# Altium schematic sheet FPGA_PWR_MISC.SchDoc(FPGA_PWR_MISC)
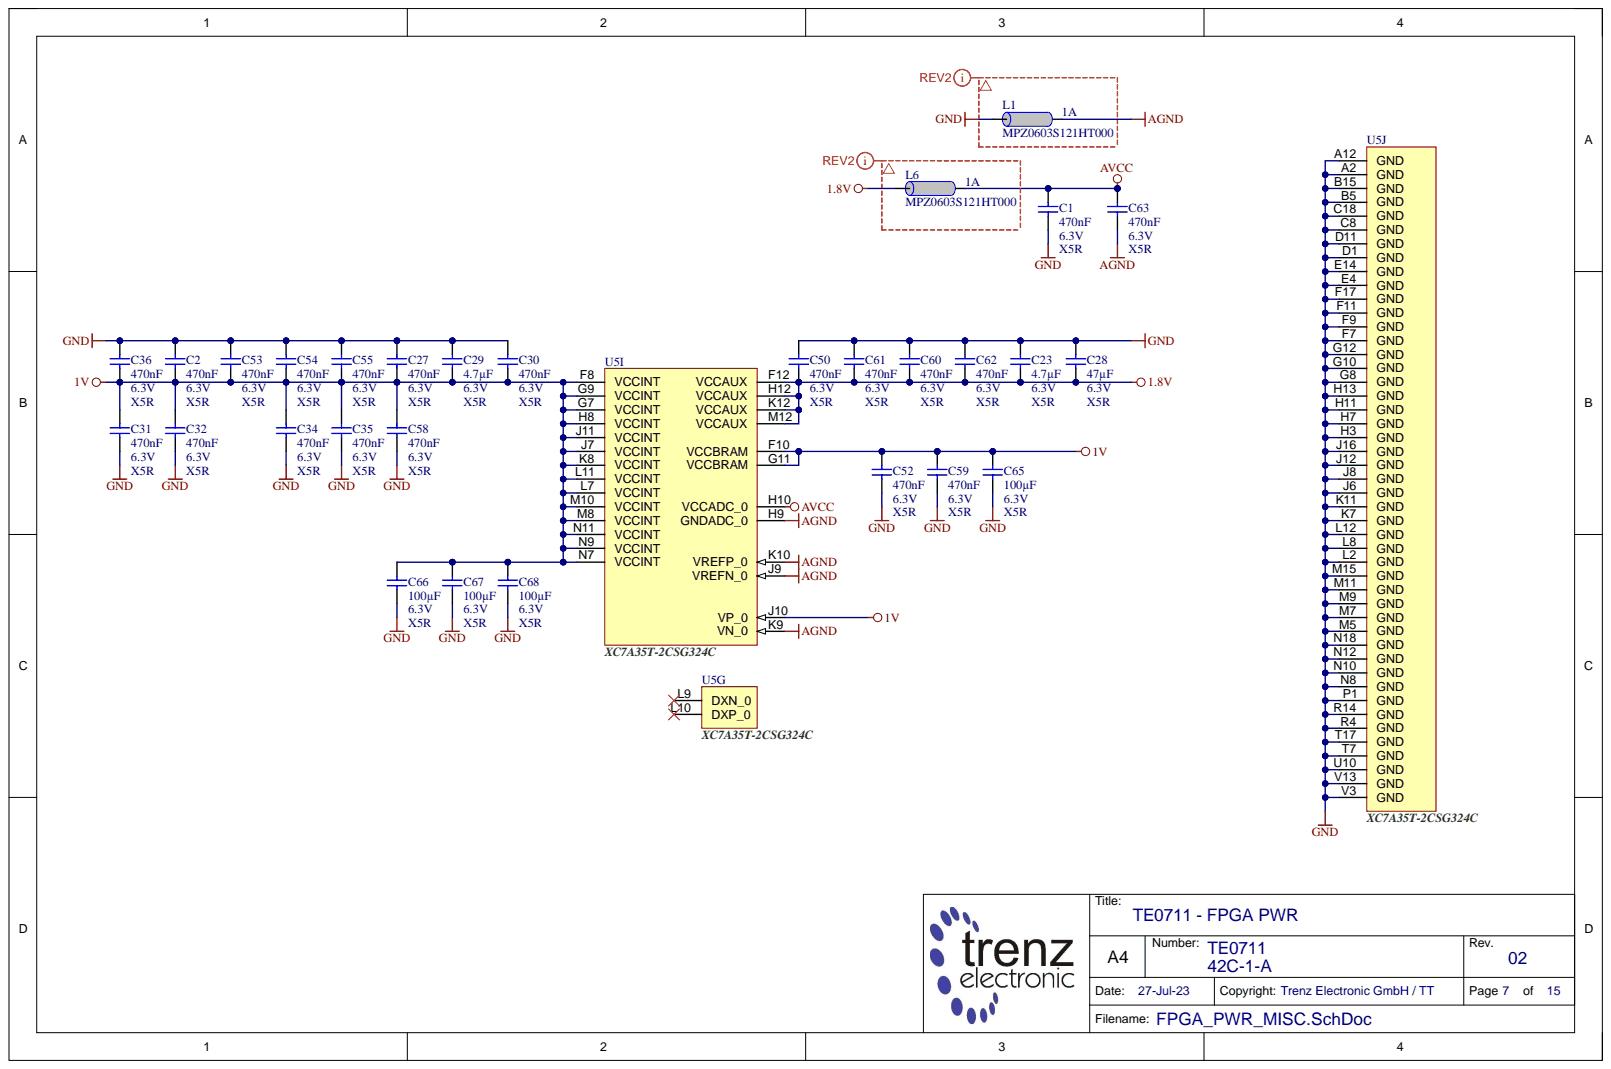
[diagram p0: FPGA_PWR_MISC.SchDoc]

## Parts
C1  470nF  Technology: X5R  Voltage: 6.3V  [CAPC0603X33N_V]
C2  470nF  Voltage: 6.3V  [CAPC0603X33N_V]
C23  4.7µF  Technology: X5R  Voltage: 6.3V  [CAPC1005X55N_V]
C27  470nF  Voltage: 6.3V  [CAPC0603X33N_V]
C28  47µF  Technology: X5R  Voltage: 6.3V  [CAPC2012X135N_V]
C29  4.7µF  Technology: X5R  Voltage: 6.3V  [CAPC1005X55N_V]
C30  470nF  Technology: X5R  Voltage: 6.3V  [CAPC0603X33N_V]
C31  470nF  Technology: X5R  Voltage: 6.3V  [CAPC0603X33N_V]
C32  470nF  Technology: X5R  Voltage: 6.3V  [CAPC0603X33N_V]
C34  470nF  Technology: X5R  Voltage: 6.3V  [CAPC0603X33N_V]
C35  470nF  Technology: X5R  Voltage: 6.3V  [CAPC0603X33N_V]
C36  470nF  Voltage: 6.3V  [CAPC0603X33N_V]
C50  470nF  Technology: X5R  Voltage: 6.3V  [CAPC0603X33N_V]
C52  470nF  Technology: X5R  Voltage: 6.3V  [CAPC0603X33N_V]
C53  470nF  Voltage: 6.3V  [CAPC0603X33N_V]
C54  470nF  Voltage: 6.3V  [CAPC0603X33N_V]
C55  470nF  Voltage: 6.3V  [CAPC0603X33N_V]
C58  470nF  Technology: X5R  Voltage: 6.3V  [CAPC0603X33N_V]
C59  470nF  Technology: X5R  Voltage: 6.3V  [CAPC0603X33N_V]
C60  470nF  Technology: X5R  Voltage: 6.3V  [CAPC0603X33N_V]
C61  470nF  Technology: X5R  Voltage: 6.3V  [CAPC0603X33N_V]
C62  470nF  Technology: X5R  Voltage: 6.3V  [CAPC0603X33N_V]
C63  470nF  Technology: X5R  Voltage: 6.3V  [CAPC0603X33N_V]
C65  100µF  Technology: X5R  Voltage: 6.3V  [CAPC3216X180N_V]
C66  100µF  Technology: X5R  Voltage: 6.3V  [CAPC3216X180N_V]
C67  100µF  Technology: X5R  Voltage: 6.3V  [CAPC3216X180N_V]
C68  100µF  Technology: X5R  Voltage: 6.3V  [CAPC3216X180N_V]
L1  MPZ0603S121HT000  Voltage: 1A  [INDC0603X33N_V]
L6  MPZ0603S121HT000  Voltage: 1A  [INDC0603X33N_V]
U5  XC7A35T-2CSG324C  [BGA324C80P18X18_1500X1500X150N]  (parts G,I,J)
U5
U5
U5
U5
U5
U5
U5
U5
U5

## Nets
1.8V: C23.1 C28.1 C50.2 C60.1 C61.1 C62.1 L6.2 U5.F12 U5.H12 U5.K12 U5.M12
1V: C2.2 C27.2 C29.1 C30.1 C31.2 C32.2 C34.2 C35.2 C36.2 C52.1 C53.2 C54.2 C55.2 C58.2 C59.1 C65.1 C66.1 C67.1 C68.1 U5.F8 U5.F10 U5.G7 U5.G9 U5.G11 U5.H8 U5.J7 U5.J10 U5.J11 U5.K8 U5.L7 U5.L11 U5.M8 U5.M10 U5.N7 U5.N9 U5.N11
AGND: C63.2 L1.1 U5.H9 U5.J9 U5.K9 U5.K10
AVCC: C1.1 C63.1 L6.1 U5.H10
GND: C1.2 C2.1 C23.2 C27.1 C28.2 C29.2 C30.2 C31.1 C32.1 C34.1 C35.1 C36.1 C50.1 C52.2 C53.1 C54.1 C55.1 C58.1 C59.2 C60.2 C61.2 C62.2 C65.2 C66.2 C67.2 C68.2 L1.2 U5.A2 U5.A12 U5.B5 U5.B15 U5.C8 U5.C18 U5.D1 U5.D11 U5.E4 U5.E14 U5.F7 U5.F9 U5.F11 U5.F17 U5.G8 U5.G10 U5.G12 U5.H3 U5.H7 U5.H11 U5.H13 U5.J6 U5.J8 U5.J12 U5.J16 U5.K7 U5.K11 U5.L2 U5.L8 U5.L12 U5.M5 U5.M7 U5.M9 U5.M11 U5.M15 U5.N8 U5.N10 U5.N12 U5.N18 U5.P1 U5.R4 U5.R14 U5.T7 U5.T17 U5.U10 U5.V3 U5.V13

Not connected: U5 L9 L10

## Library data of the parts
C1 C2 C27 C30-C32 C34-C36 C50 C52-C55 C58-C63 = 24921: KON 470nF 6.3V X5R 20%  SMD BF 0603 (0201); Database Table Name: C_0603_0201; Mixed: SMT; Preferred: Yes; Temperature-Range: -55/+85; Value: 470n; AN: 24921; Case: 0603 (0201); Component Kind: Standard; Library Name: TE.DbLib; Tolerance: 20%
  C2 C27 C36 C53-C55: Technology: X5R
C23 C29 = 24719: KON 4.7µF 6.3V X5R 20% SMD BF 1005 (0402); Database Table Name: C_1005_0402; Mixed: SMT; Preferred: Yes; Temperature-Range: -55/+85; Value: 4.7u; AN: 24719; Case: 1005 (0402); Component Kind: Standard; Library Name: TE.DbLib; Tolerance: 20%
C28 = 24718: KON 47µF 6,3V X5R 20% SMD BF 2012 (0805); Database Table Name: C_2012_0805; H-ART: CC0805MKX5R5BB476; Mixed: SMT; Preferred: Yes; Temperature-Range: -55/+85; Value: 47u; AN: 24718; Case: 2012 (0805); Component Kind: Standard; Library Name: TE.DbLib; Tolerance: 20%
C65-C68 = 23116: KON 100µF 6,3V X5R 20% SMD BF 3216 (1206); Database Table Name: C_3216_1206; Mixed: SMT; Preferred: Yes; Temperature-Range: -55/+85; Value: 100u; AN: 23116; Case: 3216 (1206); Component Kind: Standard; Library Name: TE.DbLib; Tolerance: 20%
L1 L6 = 30910: Ferritbead MPZ0603S121HT000; Database Table Name: L_0603_0201; Extra: 0,13 Ohm DC, 120 Ohm@100MHz. 1A , 25%; Mixed: SMT; Preferred: Yes; Temperature-Range: -55/+125 (Abs); Tolerance: 25%; Value: 120 Ohm; AN: 30910; Case: 0603 (0201); Component Kind: Standard; H-ART: MPZ0603S121HT000; Library Name: TE.DbLib; Storage-Temperature: -55/+125 (Abs); Technology: 0.13 Ohm; Trenz-Stock: Yes; HelpURL: b:\AD\adlib\datasheet\Murata\MPZ0603-H\
U5 = 24908: AN: 25960; Database Table Name: PROG_LOGIC; Extra: Xilinx Artix-7 FPGA 100K; H-ART: XC7A100T-2CSG324C; Mixed: SMT; Trenz-Stock: Yes; Case: CSG324; Component Kind: Standard; Description: XC7A35T-2CSG324C; Library Name: TE.DbLib; HelpURL: B:\AD\adlib\datasheet\XC7
